# Altium schematic sheet mcu.SchDoc(mcu)
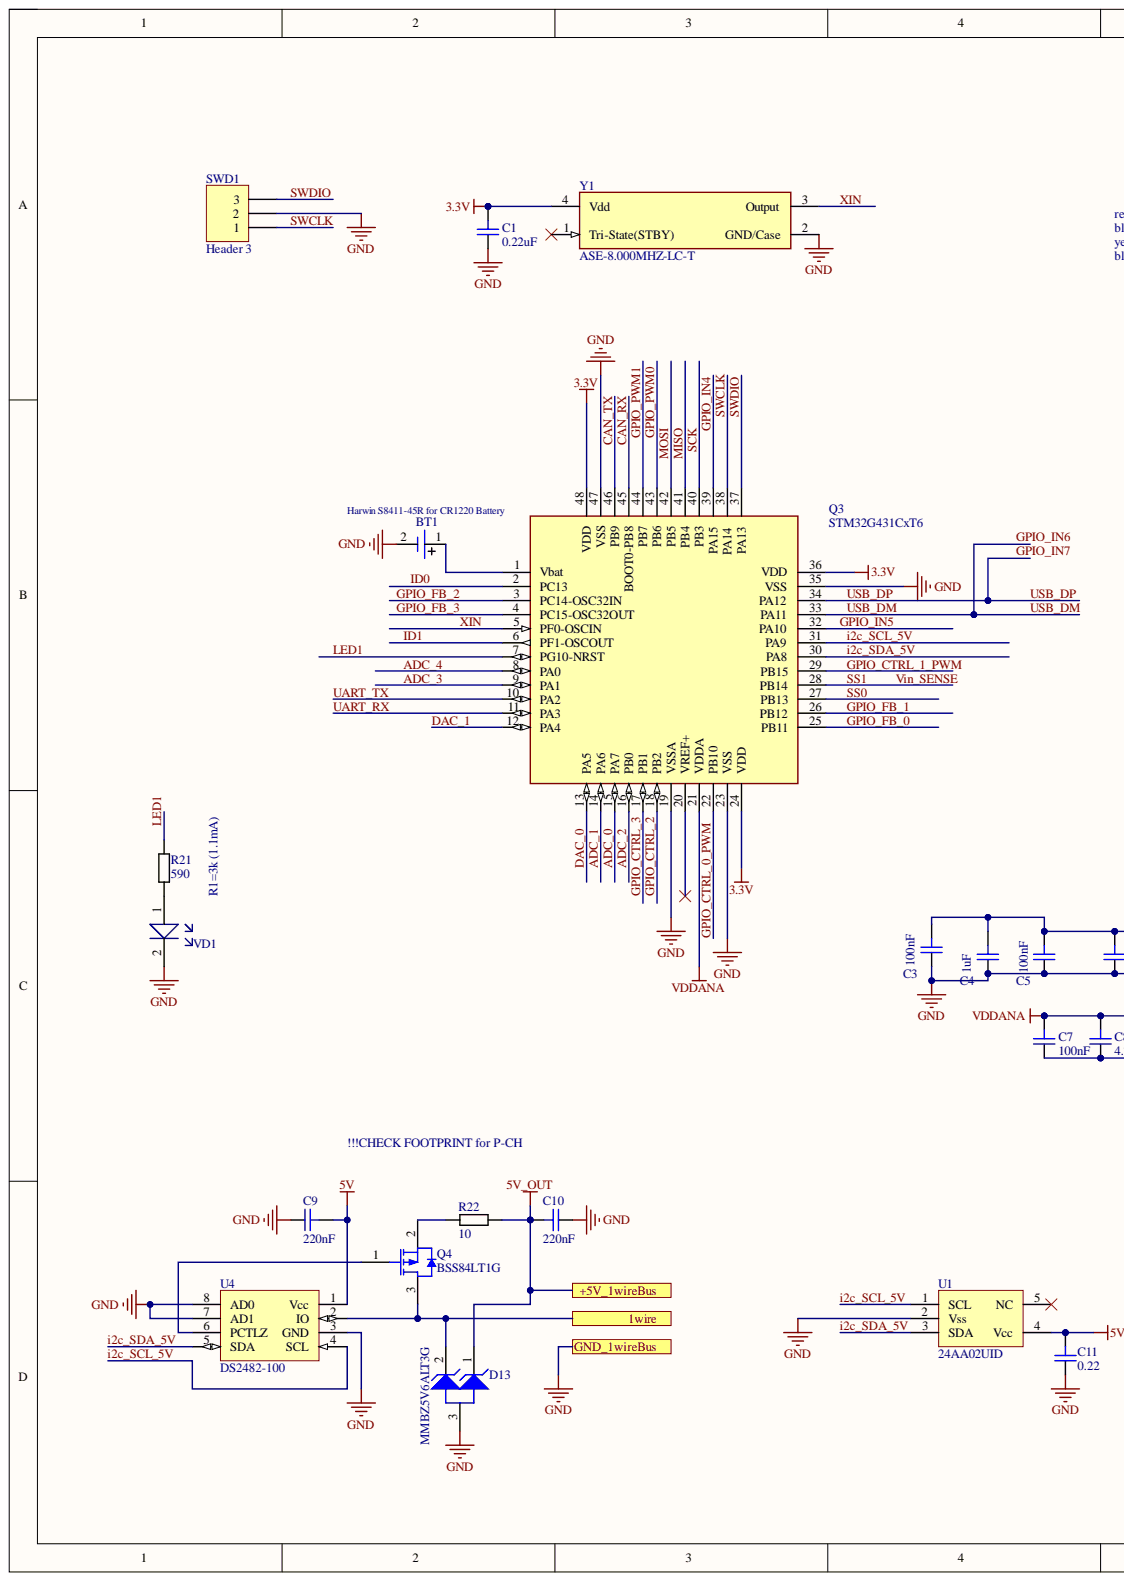
[diagram p0: mcu.SchDoc, left]
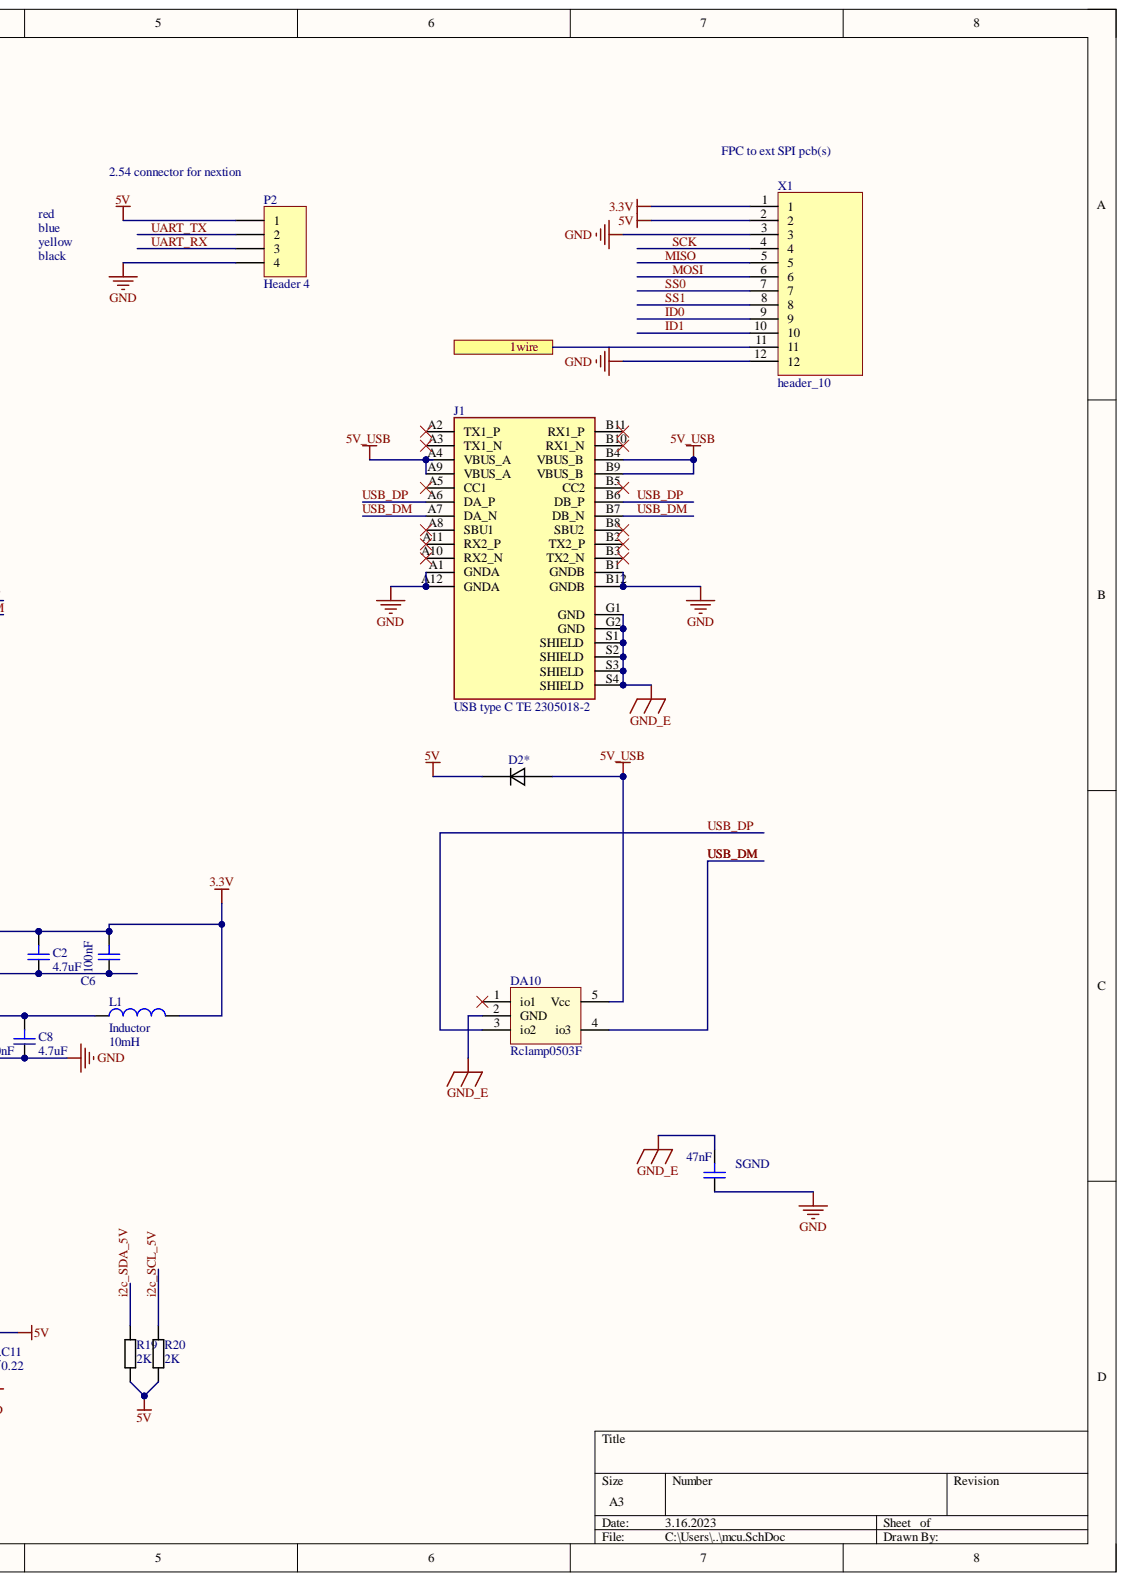
[diagram p1: mcu.SchDoc, right]

## Parts
BT1  Harwin S8411-45R for CR1220 Battery  Manufacturer Part Number: S8411-45R  [CR1220-Holder]
C1  value 0.22uF  [CAPC2012L]  (hidden comment: Cap2)
C2  value 4.7uF  [CAPC3216M]  (hidden comment: Cap2)
C3  value 100nF  [CAPC2012L]  (hidden comment: Cap2)
C4  value 1uF  [CAPC2012L]  (hidden comment: Cap2)
C5  value 100nF  [CAPC2012L]  (hidden comment: Cap2)
C6  value 100nF  [CAPC2012L]  (hidden comment: Cap2)
C7  value 100nF  [CAPC2012L]  (hidden comment: Cap2)
C8  value 4.7uF  [CAPC3216M]  (hidden comment: Cap2)
C9  value 220nF  [CAPC2012M]  (hidden comment: Cap2)
C10  value 220nF  [CAPC3216M]  (hidden comment: Cap2)
C11  value 0.22  [CAPC2012M]  (hidden comment: Cap2)
D2*  Diode 1N4002_1  [DO-214AC]  (value not drawn)
D13  MMBZ5V6ALT3G  [SOT23-3L]
DA10  Rclamp0503F  [SOT353-5N]
J1  USB type C TE 2305018-2  [TE 2305018-2]
L1  Inductor  value 10mH  [INDC3225N]
P2  Header 4  [HDR1X4]
Q3  STM32G431CxT6  [TSQFP50P900X900-48M]
Q4  BSS84LT1G  [ONSC-SOT-23-3-318-08_V]
R19  value 2K  [RESC2012L]  (hidden comment: Res3)
R20  value 2K  [RESC2012L]  (hidden comment: Res3)
R21  value 590  [RESC2012L]  (hidden comment: Res3)
R22  value 10  [RESC2012L]  (hidden comment: Res3)
SGND  value 47nF  [CAPC2012L]  (hidden comment: Cap2)
SWD1  Header 3  [HDR1X3]
U1  24AA02UID  [SOT23-5AN]
U4  DS2482-100  [SOIC-SN8_M]
VD1  (no value)  [LED2012(0805)]
X1  header_10  [FPC_0.5_12pos]
Y1  ASE-8.000MHZ-LC-T  [FP-ASE-MFG]

## Ports
1wire, +5V_1wireBus, GND_1wireBus

## Nets
3.3V: C1.2 C2.2 C3.2 C4.2 C5.2 C6.2 L1.1 Q3.24(VDD) Q3.36(VDD) Q3.48(VDD) X1.1 Y1.4(Vdd)
5V: C9.2 C11.2 D2*.1 P2.1 R19.2 R20.2 U1.4(Vcc) U4.1(Vcc) X1.2
5V_USB: D2*.2 DA10.5(Vcc) J1.A4(VBUS_A) J1.A9(VBUS_A) J1.B4(VBUS_B) J1.B9(VBUS_B)
GND_E: DA10.2(GND) J1.G1(GND) J1.G2(GND) J1.S1(SHIELD) J1.S2(SHIELD) J1.S3(SHIELD) J1.S4(SHIELD) SGND.2
VDDANA: C7.2 C8.2 L1.2 Q3.21(VDDA)
1wire: D13.2 Q4.3 U4.2(IO) X1.11 ; port 1wire
5V_OUT: C10.2 D13.1 R22.2 ; port +5V_1wireBus
ADC_0: Q3.15(PA7)
ADC_1: Q3.14(PA6)
ADC_2: Q3.16(PB0)
ADC_3: Q3.9(PA1)
ADC_4: Q3.8(PA0)
CAN_RX: Q3.45(BOOT0-PB8)
CAN_TX: Q3.46(PB9)
DAC_0: Q3.13(PA5)
DAC_1: Q3.12(PA4)
GND: BT1.2 C1.1 C2.1 C3.1 C4.1 C5.1 C6.1 C7.1 C8.1 C9.1 C10.1 C11.1 D13.3 J1.A1(GNDA) J1.A12(GNDA) J1.B1(GNDB) J1.B12(GNDB) P2.4 Q3.19(VSSA) Q3.23(VSS) Q3.35(VSS) Q3.47(VSS) SGND.1 SWD1.2 U1.2(Vss) U4.3(GND) U4.7(AD1) U4.8(AD0) VD1.2 X1.3 X1.12 Y1.2(GND/Case) ; port GND_1wireBus
GPIO_CTRL_0_PWM: Q3.22(PB10)
GPIO_CTRL_1_PWM: Q3.29(PB15)
GPIO_CTRL_2: Q3.18(PB2)
GPIO_CTRL_3: Q3.17(PB1)
GPIO_FB_0: Q3.25(PB11)
GPIO_FB_1: Q3.26(PB12)
GPIO_FB_2: Q3.3(PC14-OSC32IN)
GPIO_FB_3: Q3.4(PC15-OSC32OUT)
GPIO_IN4: Q3.39(PA15)
GPIO_IN5: Q3.32(PA10)
GPIO_IN6: DA10.4(io3) J1.A7(DA_N) J1.B7(DB_N) Q3.33(PA11)
GPIO_IN7: DA10.3(io2) J1.A6(DA_P) J1.B6(DB_P) Q3.34(PA12)
GPIO_PWM0: Q3.43(PB6)
GPIO_PWM1: Q3.44(PB7)
i2c_SCL_5V: Q3.31(PA9) R20.1 U1.1(SCL) U4.4(SCL)
i2c_SDA_5V: Q3.30(PA8) R19.1 U1.3(SDA) U4.5(SDA)
ID0: Q3.2(PC13) X1.9
ID1: Q3.6(PF1-OSCOUT) X1.10
LED1: Q3.7(PG10-NRST) R21.2
MISO: Q3.41(PB4) X1.5
MOSI: Q3.42(PB5) X1.6
SCK: Q3.40(PB3) X1.4
SS0: Q3.27(PB13) X1.7
SS1: Q3.28(PB14) X1.8
SWCLK: Q3.38(PA14) SWD1.1
SWDIO: Q3.37(PA13) SWD1.3
UART_RX: P2.3 Q3.11(PA3)
UART_TX: P2.2 Q3.10(PA2)
XIN: Q3.5(PF0-OSCIN) Y1.3(Output)
NetBT1_1: BT1.1 Q3.1(Vbat)
NetQ4_1: Q4.1 U4.6(PCTLZ)
NetQ4_2: Q4.2 R22.1
NetR21_1: R21.1 VD1.1

Not connected: DA10 1(io1); J1 A2(TX1_P) A3(TX1_N) A5(CC1) A8(SBU1) A10(RX2_N) A11(RX2_P) B2(TX2_P) B3(TX2_N) B5(CC2) B8(SBU2) B10(RX1_N) B11(RX1_P); Q3 20(VREF+); U1 5(NC); Y1 1(Tri-State(STBY))

## Library data of the parts
BT1 = CR1220_Battery: EZ-Coin Cell Battery Retainer, Horizontal SMT, for CR1220 Coin Cell Batteries; Case/Package: CR1220_Bat; Category: Connectors; Component Kind: Standard; Library Name: SA_AltiumSchLib.SchLib; Mount: SMD; Mounting Style: Surface Mount; Packaging: Reel; PP_Type: CR1220_Bat; REACH SVHC Compliance: No SVHC; RoHS: Compliant
C1-C11 SGND = Cap2: Capacitor; Component Kind: Standard; Library Name: SA_AltiumSchLib.SchLib; PackageReference: CAPR5-4X5; Published: 23-Sep-2002; Signal Integrity: Cap; Simulation: CAP; Publisher: Altium Limited
D2* = Diode 1N4002_1: 1 Amp General Purpose Rectifier; Code_JEDEC: DO-204-AL; Component Kind: Standard; ComponentLink1Description: Manufacturer Link; ComponentLink1URL: http://www.fairchildsemi.com/; ComponentLink2Description: Datasheet; ComponentLink2URL: http://www.fairchildsemi.com/ds/1N%2F1N4001.pdf; DatasheetVersion: 1998; LatestRevisionDate: 17-Jul-2002; LatestRevisionNote: Re-released for DXP Platform.; Library Name: SA_AltiumSchLib.SchLib; PackageReference: DO-41; PackageVersion: Aug-1999; Published: 8-Jun-2000; Signal Integrity: 1N4002; Simulation: 1N4002; Publisher: Altium Limited
D13 = CMP-1060-01223-1: 24 W Peak Power Zener Transient Voltage Suppressor, Dual Common Anode Zeners for ESD Protection, 3-Pin SOT-23, Pb-Free, Tape and Reel; Manufacturer: On Semiconductor; VZ Typ (V): 5.6; Component Kind: Standard; ComponentLink1Description: Manufacturer URL; ComponentLink1URL: http://www.onsemi.com/; ComponentLink2Description: Datasheet; ComponentLink2URL: http://www.onsemi.cn/pub_link/Collateral/MMBZ5V6ALT1-D.PDF; ComponentLink3Description: Package Specification; ComponentLink3URL: http://www.onsemi.cn/pub_link/Collateral/318-08.PDF; DatasheetVersion: Rev. 13; Library Name: Altium Content Vault; Mounting Technology: Surface Mount; PackageDescription: 3-Pin Small Outline Transistor, Body 2.92 x 1.3 mm, Pitch 0.95 mm; PackageReference: SOT-23-3-318-08; PackageVersion: Rev. AP, 11/2009; Packing: Tape and Reel; PartNumber: MMBZ5V6ALT3G; RoHS: Pb-Free
DA10 = Rclamp0503F: TVS Array for USB OTG Interfaces; Component Kind: Standard; Library Name: SA_AltiumSchLib.SchLib
L1 = Inductor: Code_IEC: 1005; Code_JEITA: 0402; Component Kind: Standard; Description: Inductor; LatestRevisionDate: 29-May-2009; LatestRevisionNote: IPC-7351 Footprint Added.; Library Name: SA_AltiumSchLib.SchLib; PackageDescription: Chip Inductor; PackageReference: 0402-A; Published: 8-Jun-2000; Signal Integrity: Inductor; Simulation: INDUCTOR; Publisher: Altium Limited
P2 = Header 4: Header, 4-Pin; Component Kind: Standard; LatestRevisionDate: 17-Jul-2002; LatestRevisionNote: Re-released for DXP Platform.; Library Name: Miscellaneous Connectors.IntLib; Signal Integrity: Connector; Publisher: Altium Limited
Q4 = CMP-1058-00762-1: Power MOSFET Single P-Channel, -50 V, 10 Ohm, 3-Pin SOT-23, Pb-Free, Tape and Reel; Manufacturer: On Semiconductor; Channel Polarity: P Channel; Ciss Typ (pF): 36; Coss Typ (pF): 17; Crss Typ (pF): 6.5; ID Max (A): 0.13; PD Max (W): 0.225; V(BR)DSS Min (V): 50; VGS Max (V): 20; VGS(th) Max (V): 2; Component Kind: Standard; ComponentLink1Description: Manufacturer URL; ComponentLink1URL: http://www.onsemi.com/; ComponentLink2Description: Datasheet; ComponentLink2URL: http://www.onsemi.com/pub_link/Collateral/BSS84LT1-D.PDF; ComponentLink3Description: Package Specification; ComponentLink3URL: http://www.onsemi.cn/pub_link/Collateral/318-08.PDF; Configuration: Single; DatasheetVersion: Rev. 8; Library Name: Altium Content Vault; Mounting Technology: Surface Mount; Package Type: SOT-23-3; PackageDescription: 3-Pin Small Outline Transistor, Body 2.92 x 1.3 mm, Pitch 0.95 mm; PackageReference: SOT-23-3-318-08; PackageVersion: Rev. AP, 11/2009; Packing: Tape and Reel; PartNumber: BSS84LT1G; RoHS: Pb-Free
R19-R22 = Res3: Resistor; Component Kind: Standard; LatestRevisionDate: 29-May-2009; LatestRevisionNote: IPC-7351 Footprint Added.; Library Name: SA_AltiumSchLib.SchLib; PackageDescription: Chip Resistor; PackageReference: J1-0603; Published: 8-Jun-2000; Signal Integrity: Res; Simulation: RESISTOR; Publisher: Altium Limited
SWD1 = Header 3: Header, 3-Pin; Component Kind: Standard; LatestRevisionDate: 17-Jul-2002; LatestRevisionNote: Re-released for DXP Platform.; Library Name: Miscellaneous Connectors.IntLib; Signal Integrity: Connector; Publisher: Altium Limited
U1 = 24AA02UID: eeprom I2C 2kbit w UID; Component Kind: Standard; Library Name: SA_AltiumSchLib.SchLib
U4 = DS2482-100: 1-Wire Master I2C; Component Kind: Standard; Library Name: SA_AltiumSchLib.SchLib
VD1 = LED: smd led; Component Kind: Standard; Library Name: SA_AltiumSchLib.SchLib
X1 = header_12: Component Kind: Standard; Description: Header_10; Library Name: SA_AltiumSchLib.SchLib
Y1 = CMP-2000-05795-2: XTAL OSC XO 25.0000MHZ CMOS SMD; Manufacturer: Abracon; Manufacturer Part Number: ASE-25.000MHZ-LC-T; Frequency: 25MHz; Load Capacitance: 15pF; Max Supply Current: 13mA; Max Supply Voltage: 3.465V; Min Supply Voltage: 3.135V; Stability: 50ppm; Automotive: No; Category: Crys; Component Kind: Standard; ComponentLink2Description: Datasheet; ComponentLink2URL: https://abracon.com/Oscillators/ASEseries.pdf; Device Class L1: Passive Components; Device Class L2: Crystals / Oscillators; Device Class L3: Oscillators; Digikey Description: XTAL OSC XO 25.0000MHZ CMOS SMD; Height: 1.2mm; Lead Free: Yes; Library Name: Altium Content Vault; Octopart: https://octopart.com/ase-25.000mhz-lc-t-abracon-657858; Package: ASE; RoHS: TRUE; Series: ASE; Temperature: -40°C to +85°C; Temperature Range High: +85°C; Temperature Range Low: -40°C
J1 = USB type C TE 2305018-2: Component Kind: Standard; Library Name: SA_AltiumSchLib.SchLib
Q3 = STM32G431CxT6: Component Kind: Standard; Library Name: SA_AltiumSchLib.SchLib
